# Altium schematic sheet Half Bridge.SchDoc
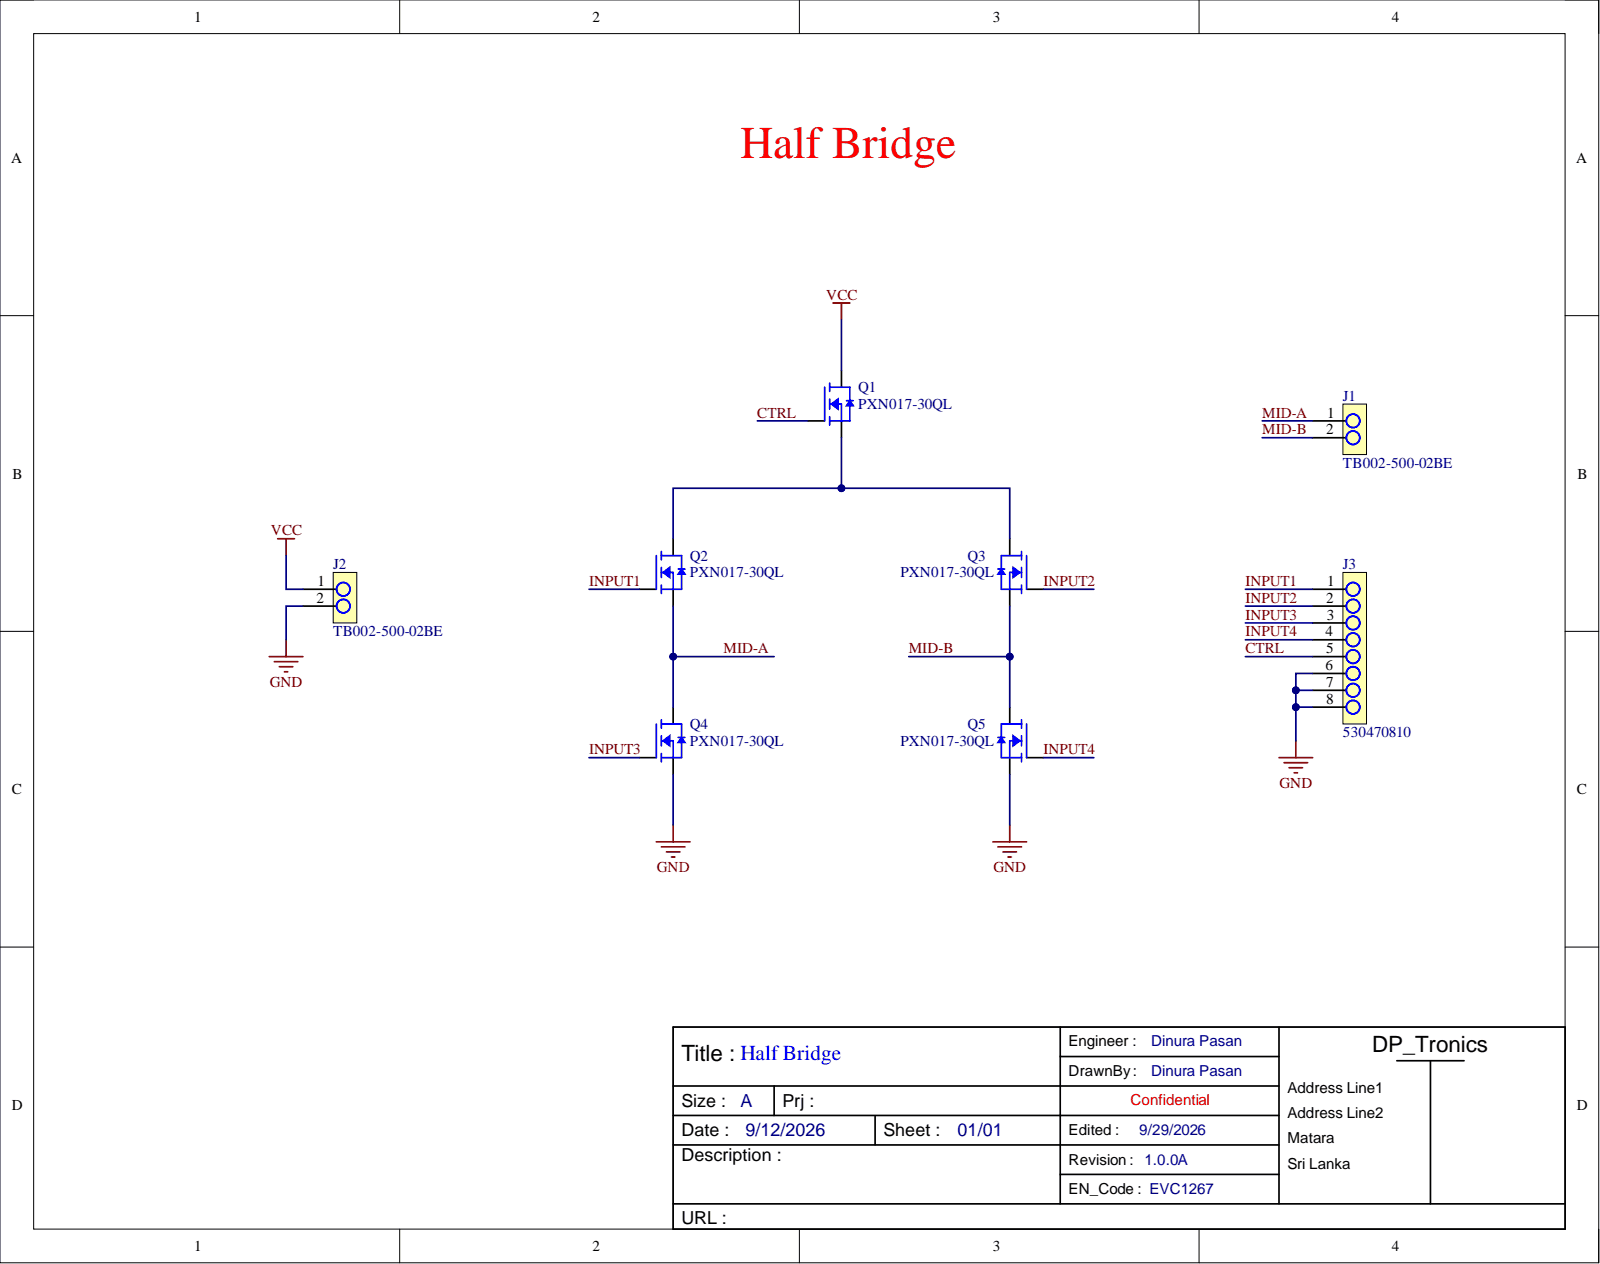
[diagram p0: Half Bridge.SchDoc]

## Parts
J1  TB002-500-02BE  [TB002-500-02BE]
J2  TB002-500-02BE  [TB002-500-02BE]
J3  530470810  [530470810]
Q1  PXN017-30QL  [NEXPERIA-MLPAK33]
Q2  PXN017-30QL  [NEXPERIA-MLPAK33]
Q3  PXN017-30QL  [NEXPERIA-MLPAK33]
Q4  PXN017-30QL  [NEXPERIA-MLPAK33]
Q5  PXN017-30QL  [NEXPERIA-MLPAK33]

## Nets
GND: J2.2 J3.6 J3.7 J3.8 Q4.S Q5.S
VCC: J2.1 Q1.D
CTRL: J3.5 Q1.G
INPUT1: J3.1 Q2.G
INPUT2: J3.2 Q3.G
INPUT3: J3.3 Q4.G
INPUT4: J3.4 Q5.G
MID-A: J1.1 Q2.S Q4.D
MID-B: J1.2 Q3.S Q5.D
NetQ1_S: Q1.S Q2.D Q3.D

## Library data of the parts
J1 J2 = TB002-500-02BE: 2 Position Wire to Board Terminal Block Horizontal with Board 0.197" (5.00mm) Through Hole; Manufacturer 1: Same Sky; Approvals: CE, EN, IEC, UL, cUL; Category 1: Terminal Blocks; Current Rating: 15A; Description 1: 2~24 Poles, Screw Type, Horizontal, 5.0 Pitch, 22~12 (AWG), Terminal Block Connector; Mount 1: Screw; Number of Contacts: 2; Number of Levels: 1; Pitch: 5mm; Voltage Rating: 300V; Wire/Cable Gauge: 12AWG; Color: Blue; Component Kind: Standard; ComponentLink1Description: https://datasheet.octopart.com:443/TB002-500-02BE-Same-Sky-datasheet-181920249.pdf?src-supplier=Same+Sky; ComponentLink1URL: https://datasheet.octopart.com:443/TB002-500-02BE-Same-Sky-datasheet-181920249.pdf?src-supplier=Same+Sky; Export Control Classification Number (ECCN) Code: EAR99; Harmonized Tariff Schedule (HTS) Code: 8536.90.40.00; Height: 10mm; Introduction Date: 2024-10-09; Library Name: Common_library.IntLib; Lifecycle Status: Production; Manufacturer Part Number 1: TB002-500-02BE; Max Operating Temperature 1: 105°C; Min Operating Temperature 1: -40°C; Number of Pins 1: 2; Number of Rows: 1; Orientation: Horizontal; REACH SVHC: Affected; RoHS 1: Compliant; Supplier 1: DigiKey; Supplier Part Number 1: 102-6145-ND; Width: 7.6mm
J3 = 530470810: Connector Header Through Hole 8 position 0.049" (1.25mm); Manufacturer 1: Molex; Manufacturer Lifecycle Status: ACTIVE; Manufacturer Part Number 1: 53047-0810; Case/Package 1: 0810; Category 1: Headers and Wire Housings; Contact Resistance: 20mΩ; Current Rating: 1A; Description 1: Conn Shrouded Header (4 Sides) HDR 8 POS 1.25mm Solder ST Top Entry Thru-Hole PicoBlade Tray; Dielectric Material: Polyamide; Gender: Male; Glow Wire Compliant: Non-compliant; Insulation Resistance: 100MΩ; Number of Circuits: 7; Number of Contacts: 8; Plating: Tin; Voltage Rating: 125V; China RoHS: Compliant; Color: Natural; Component Kind: Standard; ComponentLink1Description: https://datasheet.octopart.com:443/53047-0810-Molex-datasheet-138898128.pdf?src-supplier=Sager+Electronics; ComponentLink1URL: https://datasheet.octopart.com:443/53047-0810-Molex-datasheet-138898128.pdf?src-supplier=Sager+Electronics; ComponentLink2Description: https://datasheet.octopart.com:443/53047-0810-Molex-datasheet-13785974.pdf?src-supplier=Sager+Electronics; ComponentLink2URL: https://datasheet.octopart.com:443/53047-0810-Molex-datasheet-13785974.pdf?src-supplier=Sager+Electronics; ComponentLink3Description: https://sigma.octopart.com:443/138898116/technical_drawing/Molex-53047-0810.pdf?src-supplier=IHS; ComponentLink3URL: https://sigma.octopart.com:443/138898116/technical_drawing/Molex-53047-0810.pdf?src-supplier=IHS; ComponentLink4Description: https://datasheet.octopart.com:443/530470810-Molex-datasheet-8334299.pdf?src-supplier=Molex; ComponentLink4URL: https://datasheet.octopart.com:443/530470810-Molex-datasheet-8334299.pdf?src-supplier=Molex; ComponentLink5Description: https://datasheet.octopart.com:443/53047-0810-Molex-datasheet-38191.pdf?src-supplier=TME; ComponentLink5URL: https://datasheet.octopart.com:443/53047-0810-Molex-datasheet-38191.pdf?src-supplier=TME; ComponentLink6Description: https://datasheet.octopart.com:443/53047-0810-Molex-datasheet-154755961.pdf?src-supplier=Master+Electronics; ComponentLink6URL: https://datasheet.octopart.com:443/53047-0810-Molex-datasheet-154755961.pdf?src-supplier=Master+Electronics; ComponentLink7Description: https://datasheet.octopart.com:443/53047-0810-Molex-datasheet-101583379.pdf?src-supplier=Farnell; ComponentLink7URL: https://datasheet.octopart.com:443/53047-0810-Molex-datasheet-101583379.pdf?src-supplier=Farnell; ComponentLink8Description: https://datasheet.octopart.com:443/53047-0810-Molex-datasheet-14711287.pdf?src-supplier=Farnell; ComponentLink8URL: https://datasheet.octopart.com:443/53047-0810-Molex-datasheet-14711287.pdf?src-supplier=Farnell; ComponentLink9Description: https://datasheet.octopart.com:443/53047-0810-Molex-datasheet-5313088.pdf?src-supplier=Farnell; ComponentLink9URL: https://datasheet.octopart.com:443/53047-0810-Molex-datasheet-5313088.pdf?src-supplier=Farnell; ComponentLink10Description: https://datasheet.octopart.com:443/53047-0810-Molex-datasheet-33003857.pdf?src-supplier=Electro+Sonic; ComponentLink10URL: https://datasheet.octopart.com:443/53047-0810-Molex-datasheet-33003857.pdf?src-supplier=Electro+Sonic; ComponentLink11Description: https://datasheet.octopart.com:443/53047-0810-Molex-datasheet-62105410.pdf?src-supplier=Electro+Sonic; ComponentLink11URL: https://datasheet.octopart.com:443/53047-0810-Molex-datasheet-62105410.pdf?src-supplier=Electro+Sonic; ComponentLink12Description: https://datasheet.octopart.com:443/53047-0810-Molex-datasheet-23710544.pdf?src-supplier=Electro+Sonic; ComponentLink12URL: https://datasheet.octopart.com:443/53047-0810-Molex-datasheet-23710544.pdf?src-supplier=Electro+Sonic; ComponentLink13Description: https://datasheet.octopart.com:443/53047-0810-Molex-datasheet-140186535.pdf?src-supplier=RS+(Formerly+Allied+Electronics); ComponentLink13URL: https://datasheet.octopart.com:443/53047-0810-Molex-datasheet-140186535.pdf?src-supplier=RS+(Formerly+Allied+Electronics); ComponentLink14Description: https://datasheet.octopart.com:443/53047-0810-Molex-datasheet-120153815.pdf?src-supplier=RS+(Formerly+Allied+Electronics); ComponentLink14URL: https://datasheet.octopart.com:443/53047-0810-Molex-datasheet-120153815.pdf?src-supplier=RS+(Formerly+Allied+Electronics); ComponentLink15Description: https://datasheet.octopart.com:443/530470810-Molex-datasheet-137316104.pdf?src-supplier=Future+Electronics; ComponentLink15URL: https://datasheet.octopart.com:443/530470810-Molex-datasheet-137316104.pdf?src-supplier=Future+Electronics; ComponentLink16Description: https://datasheet.octopart.com:443/53047-0810-Molex-datasheet-33003856.pdf?src-supplier=Newark; ComponentLink16URL: https://datasheet.octopart.com:443/53047-0810-Molex-datasheet-33003856.pdf?src-supplier=Newark; ComponentLink17Description: https://datasheet.octopart.com:443/53047-0810-Molex-datasheet-21208624.pdf?src-supplier=Newark; ComponentLink17URL: https://datasheet.octopart.com:443/53047-0810-Molex-datasheet-21208624.pdf?src-supplier=Newark; ComponentLink18Description: https://datasheet.octopart.com:443/53047-0810-Molex-datasheet-17741837.pdf?src-supplier=Jameco; ComponentLink18URL: https://datasheet.octopart.com:443/53047-0810-Molex-datasheet-17741837.pdf?src-supplier=Jameco; ComponentLink19Description: https://datasheet.octopart.com:443/53047-0810-Molex-datasheet-144844.pdf?src-supplier=DigiKey; ComponentLink19URL: https://datasheet.octopart.com:443/53047-0810-Molex-datasheet-144844.pdf?src-supplier=DigiKey; ComponentLink20Description: https://datasheet.octopart.com:443/53047-0810-Molex-datasheet-100429.pdf?src-supplier=Mouser; ComponentLink20URL: https://datasheet.octopart.com:443/53047-0810-Molex-datasheet-100429.pdf?src-supplier=Mouser; ComponentLink21Description: http://sigma.octopart.com:80/16412934/rohs_statement/Molex-53047-0810.pdf?src-supplier=Compliance+Statements; ComponentLink21URL: http://sigma.octopart.com:80/16412934/rohs_statement/Molex-53047-0810.pdf?src-supplier=Compliance+Statements; ComponentLink22Description: http://sigma.octopart.com:80/reach_statement/Molex-company-74.pdf?src-supplier=Compliance+Statements; ComponentLink22URL: http://sigma.octopart.com:80/reach_statement/Molex-company-74.pdf?src-supplier=Compliance+Statements; ComponentLink23Description: http://sigma.octopart.com:80/rohs_statement/Molex-company-73.pdf?src-supplier=Compliance+Statements; ComponentLink23URL: http://sigma.octopart.com:80/rohs_statement/Molex-company-73.pdf?src-supplier=Compliance+Statements; ComponentLink24Description: http://sigma.octopart.com:80/conflict_mineral_statement/Molex-company-72.pdf?src-supplier=Compliance+Statements; ComponentLink24URL: http://sigma.octopart.com:80/conflict_mineral_statement/Molex-company-72.pdf?src-supplier=Compliance+Statements; Contact Gender: Male; Contact Material: Tin; Contact Plating: Tin; Country of Origin: MX; Flammability Rating: UL94 V-0; Height: 4.4mm; Housing Color: Natural; Housing Material: Polyamide; Lead Free 1: Lead Free; Lead Pitch: 1.25mm; Library Name: Components02.IntLib; Lifecycle Status: Production; Max Operating Temperature 1: 85°C; Min Operating Temperature 1: -40°C; Mount 1: Through Hole; Number of Pins 1: 8; Number of Positions: 8; Number of Rows: 1; Orientation: Vertical; Pitch: 1.25mm; Radiation Hardening: No; REACH SVHC: Yes; RoHS 1: Compliant; Schedule B: 8536694040, 8536694040|8536694040|8536694040|8536694040|8536694040; Supplier 1: LCSC; Supplier Part Number 1: C240845; Terminal Pitch: 1.25mm; Termination: Through Hole; Voltage Rating (AC): 125V; Voltage Rating (DC): 125V
Q1-Q5 = PXN017-30QL: N-Channel 30 V 7.9A (Ta), 20A (Tc) 1.7W (Ta), 10.9W (Tc) Surface Mount MLPAK33; Manufacturer: Nexperia; Configuration: Single; Drain Current - Continuous (Id): 12A; Drain Current - Pulsed (Id): 163A; Drain to Source Voltage (Vdss): 30V; Eco Plan: RoHS; Gate Charge (Qg) (Max) @ Vgs: 7.7 nC @ 10 V; Gate Level: Logic; Gate−Source Voltage(Vgss): ±20V; Input Capacitance (Ciss) (Max) @ Vds: 350 pF @ 15 V; Rds On (Max) @ Id, Vgs: 17.4mOhm @ 7.9A, 10V; Vgs(th) (Max) @ Id: 2.2V @ 250µA; Category: Power MOSFET; Channel Polarity: N-Channel; Component Kind: Standard; Datasheet: https://assets.nexperia.com/documents/data-sheet/PXN017-30QL.pdf; Library Name: Transistors.IntLib; Operating Temperature: -55°C ~ 150°C (TJ); Power Dissipation (Max): 1.7W (Ta); Ratings: -; Series: TrenchMOS; Simulation: PXN017-30QL; Supplier 1: Digi-Key; Supplier Part Number 1: 1727-PXN017-30QLJCT-ND
